# Altium schematic sheet 01 HK4530 V2.1  IO.SchDoc
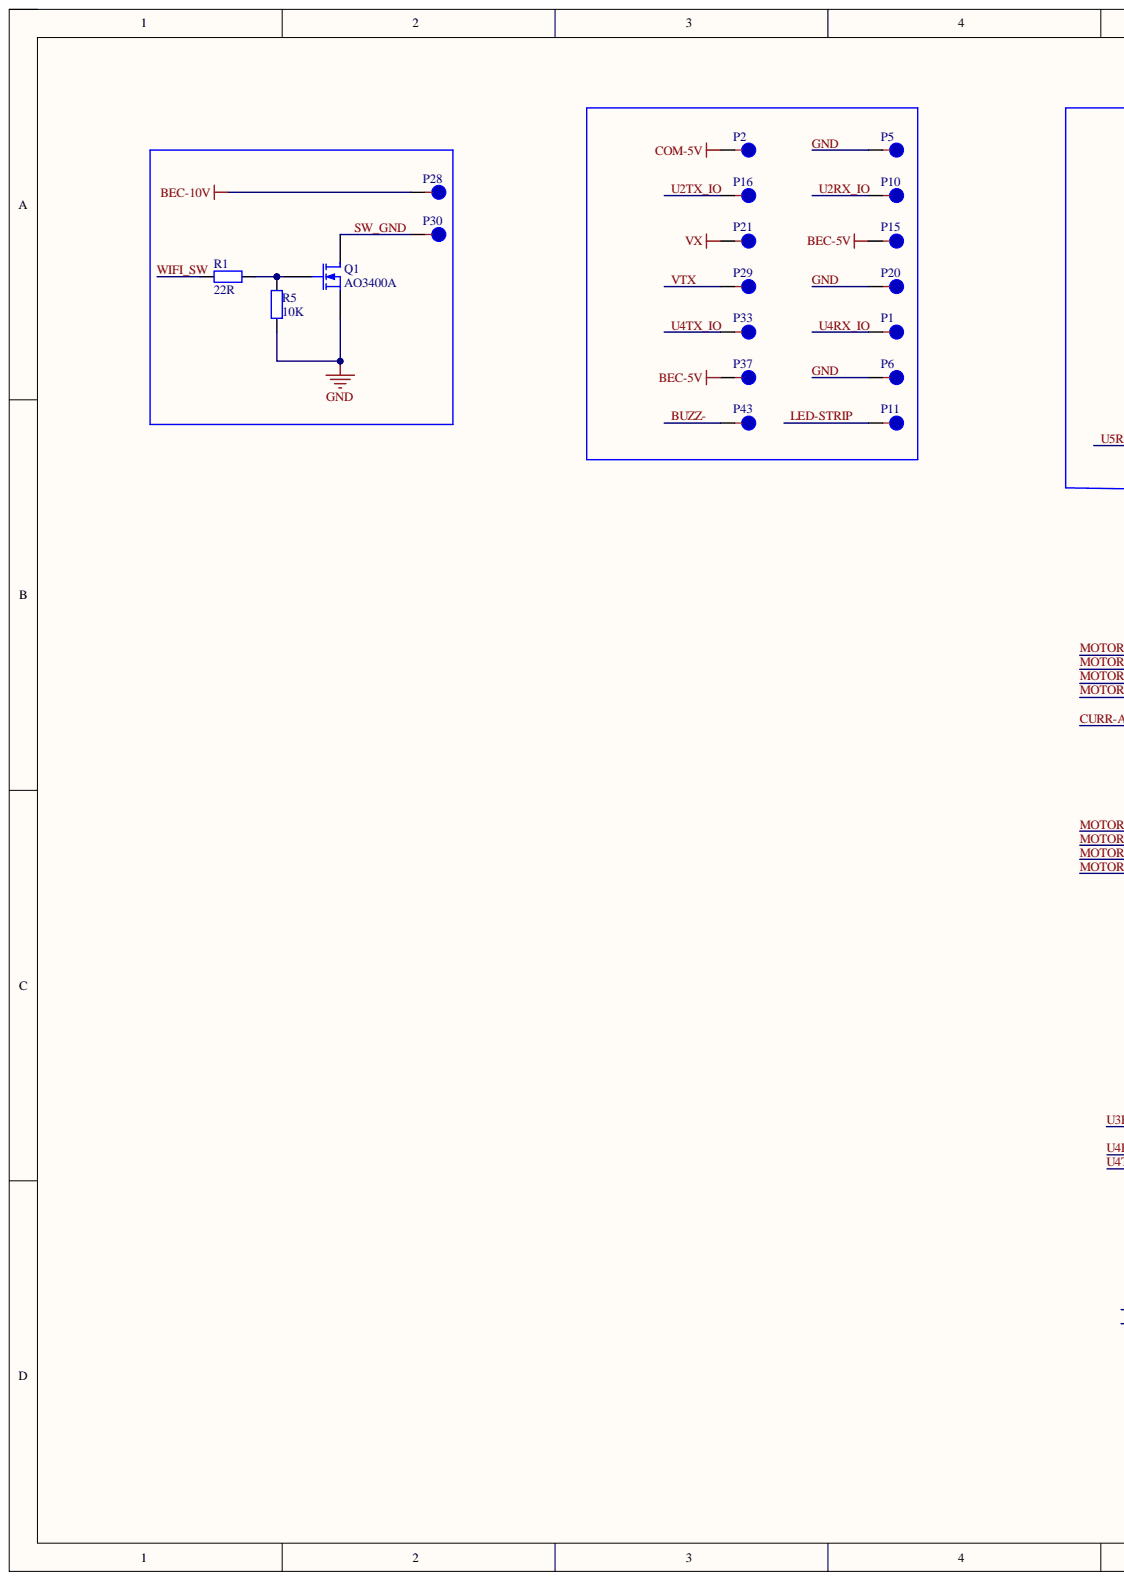
[diagram p0: 01 HK4530 V2.1  IO.SchDoc, left]
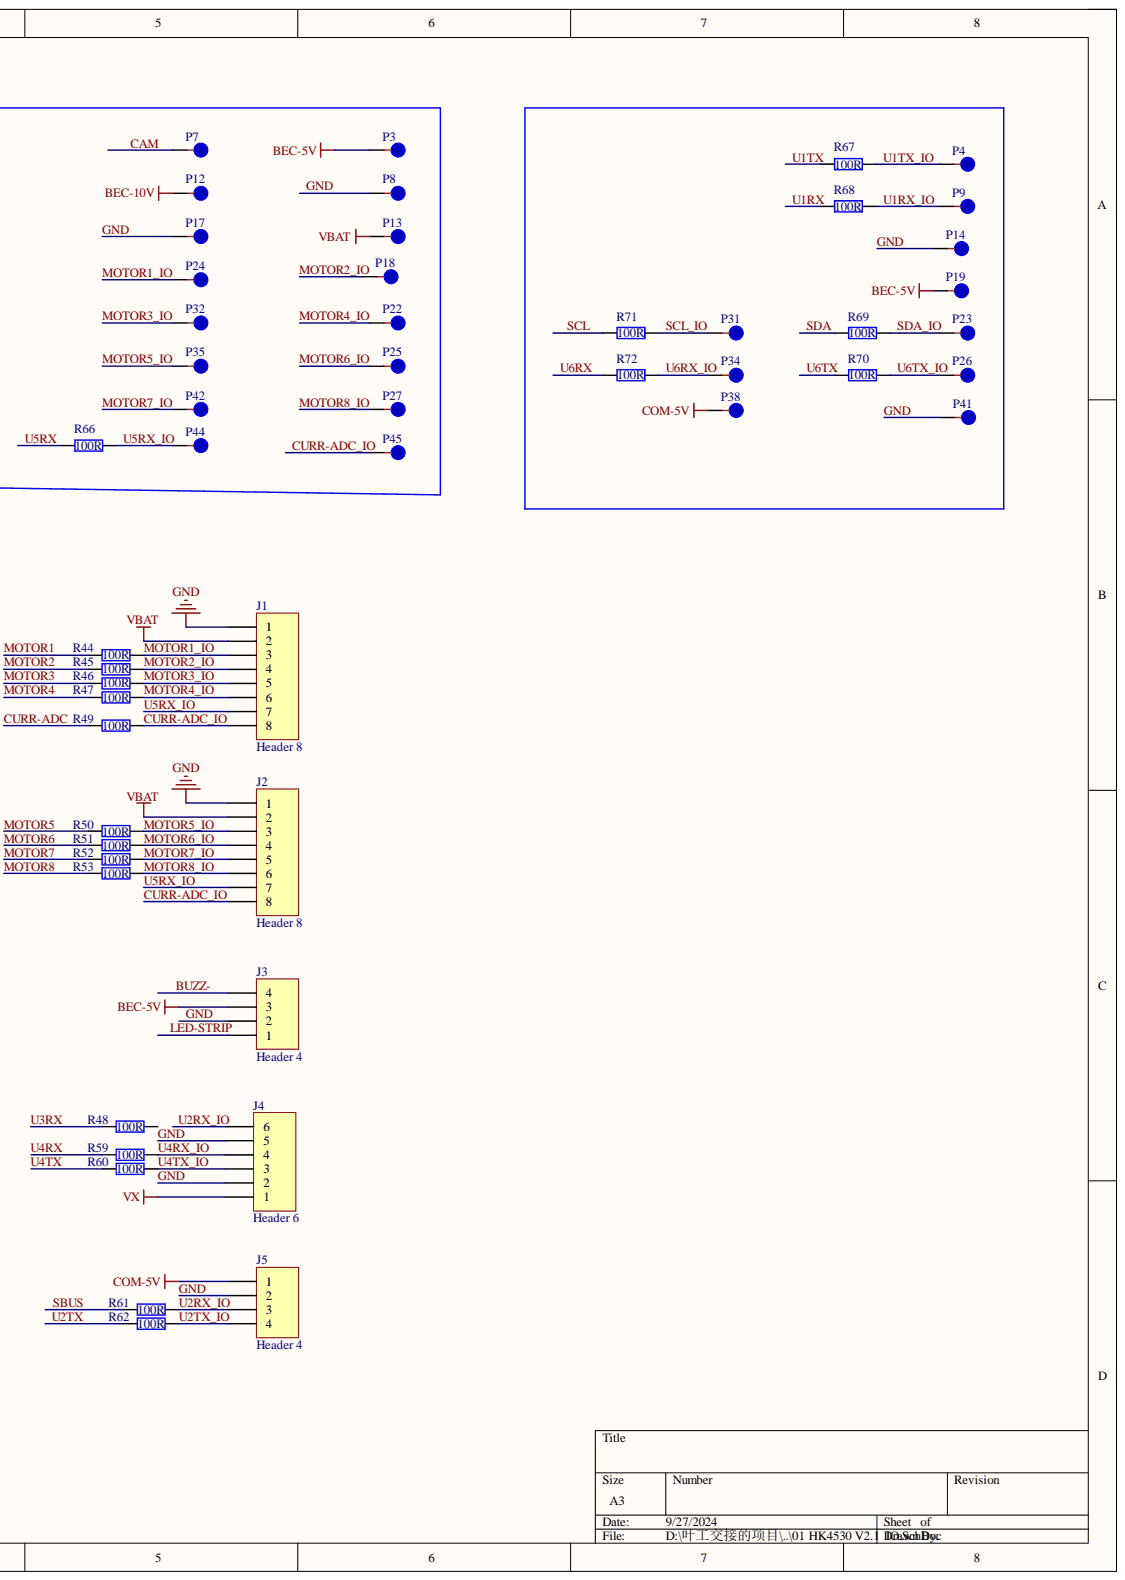
[diagram p1: 01 HK4530 V2.1  IO.SchDoc, right]

## Parts
J1  Header 8  [8PIN]
J2  Header 8  [8PIN]
J3  Header 4  [4PIN]
J4  Header 6  [6PIN]
J5  Header 4  [4PIN]
P1  (no value)  [TP08]
P2  (no value)  [TP08]
P3  (no value)  [TP08]
P4  (no value)  [TP08]
P5  (no value)  [TP08]
P6  (no value)  [TP08]
P7  (no value)  [TP08]
P8  (no value)  [TP08]
P9  (no value)  [TP08]
P10  (no value)  [TP08]
P11  (no value)  [TP08]
P12  (no value)  [TP08]
P13  (no value)  [TP08]
P14  (no value)  [TP08]
P15  (no value)  [TP08]
P16  (no value)  [TP08]
P17  (no value)  [TP08]
P18  (no value)  [TP08]
P19  (no value)  [TP08]
P20  (no value)  [TP08]
P21  (no value)  [TP08]
P22  (no value)  [TP08]
P23  (no value)  [TP08]
P24  (no value)  [TP08]
P25  (no value)  [TP08]
P26  (no value)  [TP08]
P27  (no value)  [TP08]
P28  (no value)  [TP08]
P29  (no value)  [TP08]
P30  (no value)  [TP08]
P31  (no value)  [TP08]
P32  (no value)  [TP08]
P33  (no value)  [TP08]
P34  (no value)  [TP08]
P35  (no value)  [TP08]
P37  (no value)  [TP08]
P38  (no value)  [TP08]
P41  (no value)  [TP08]
P42  (no value)  [TP08]
P43  (no value)  [TP08]
P44  (no value)  [TP08]
P45  (no value)  [TP08]
Q1  AO3400A  [SOT23-3]
R1  22R  [R0201]
R5  10K  [R0201]
R44  100R  [R0201]
R45  100R  [R0201]
R46  100R  [R0201]
R47  100R  [R0201]
R48  value 100R  [R0201]  (hidden comment: NC)
R49  100R  [R0201]
R50  100R  [R0201]
R51  100R  [R0201]
R52  100R  [R0201]
R53  100R  [R0201]
R59  100R  [R0201]
R60  100R  [R0201]
R61  100R  [R0201]
R62  100R  [R0201]
R66  100R  [R0201]
R67  100R  [R0201]
R68  100R  [R0201]
R69  100R  [R0201]
R70  100R  [R0201]
R71  100R  [R0201]
R72  100R  [R0201]

## Nets
BEC-5V: J3.3 P3.1 P15.1 P19.1 P37.1
BEC-10V: P12.1 P28.1
COM-5V: J5.1 P2.1 P38.1
VBAT: J1.2 J2.2 P13.1
VX: J4.1 P21.1
BUZZ-: J3.4 P43.1
CAM: P7.1
CURR-ADC: R49.1
CURR-ADC_IO: J1.8 J2.8 P45.1 R49.2
GND: J1.1 J2.1 J3.2 J4.2 J4.5 J5.2 P5.1 P6.1 P8.1 P14.1 P17.1 P20.1 P41.1 Q1.2 R5.1
LED-STRIP: J3.1 P11.1
MOTOR1: R44.1
MOTOR1_IO: J1.3 P24.1 R44.2
MOTOR2: R45.1
MOTOR2_IO: J1.4 P18.1 R45.2
MOTOR3: R46.1
MOTOR3_IO: J1.5 P32.1 R46.2
MOTOR4: R47.1
MOTOR4_IO: J1.6 P22.1 R47.2
MOTOR5: R50.1
MOTOR5_IO: J2.3 P35.1 R50.2
MOTOR6: R51.1
MOTOR6_IO: J2.4 P25.1 R51.2
MOTOR7: R52.1
MOTOR7_IO: J2.5 P42.1 R52.2
MOTOR8: R53.1
MOTOR8_IO: J2.6 P27.1 R53.2
SBUS: R61.1
SCL: R71.1
SCL_IO: P31.1 R71.2
SDA: R69.1
SDA_IO: P23.1 R69.2
SW_GND: P30.1 Q1.3
U1RX: R68.1
U1RX_IO: P9.1 R68.2
U1TX: R67.1
U1TX_IO: P4.1 R67.2
U2RX_IO: J4.6 J5.3 P10.1 R61.2
U2TX: R62.1
U2TX_IO: J5.4 P16.1 R62.2
U3RX: R48.1
U4RX: R59.1
U4RX_IO: J4.4 P1.1 R59.2
U4TX: R60.1
U4TX_IO: J4.3 P33.1 R60.2
U5RX: R66.1
U5RX_IO: J1.7 J2.7 P44.1 R66.2
U6RX: R72.1
U6RX_IO: P34.1 R72.2
U6TX: R70.1
U6TX_IO: P26.1 R70.2
VTX: P29.1
WIFI_SW: R1.1
NetQ1_1: Q1.1 R1.2 R5.2

Not connected: R48 2

## Library data of the parts
J1 J2 = Header 8: Header, 8-Pin; Component Kind: Standard; LatestRevisionDate: 17-Jul-2002; LatestRevisionNote: Re-released for DXP Platform.; Library Name: Miscellaneous Connectors.IntLib; Signal Integrity: Connector; Publisher: Altium Limited
J3 J5 = Header 4: Header, 4-Pin; Component Kind: Standard; LatestRevisionDate: 17-Jul-2002; LatestRevisionNote: Re-released for DXP Platform.; Library Name: Miscellaneous Connectors.IntLib; Signal Integrity: Connector; Publisher: Altium Limited
J4 = Header 6: Header, 6-Pin; Component Kind: Standard; LatestRevisionDate: 17-Jul-2002; LatestRevisionNote: Re-released for DXP Platform.; Library Name: Miscellaneous Connectors.IntLib; Signal Integrity: Connector; Publisher: Altium Limited
P1-P35 P37 P38 P41-P45 = TP: Component Kind: Standard
R1 R5 R44-R53 R59-R62 R66-R72 = R0201: Resistor; Component Kind: Standard
  R1 R5: Library Name: Integrated_Library_gr.IntLib
  R44-R47 R49-R53 R59-R62 R66-R72: Library Name: AT32F421K8U7temp V1.0.SCHLIB; Value: 100R
  R48: Library Name: AT32F421K8U7temp V1.0.SCHLIB
Q1 = AO3400A: Component Kind: Standard; Library Name: Schlib-HK.SchLib
